# Altium schematic sheet 03_POWER_REGULATORS.SchDoc(03_POWER_REGULATORS)
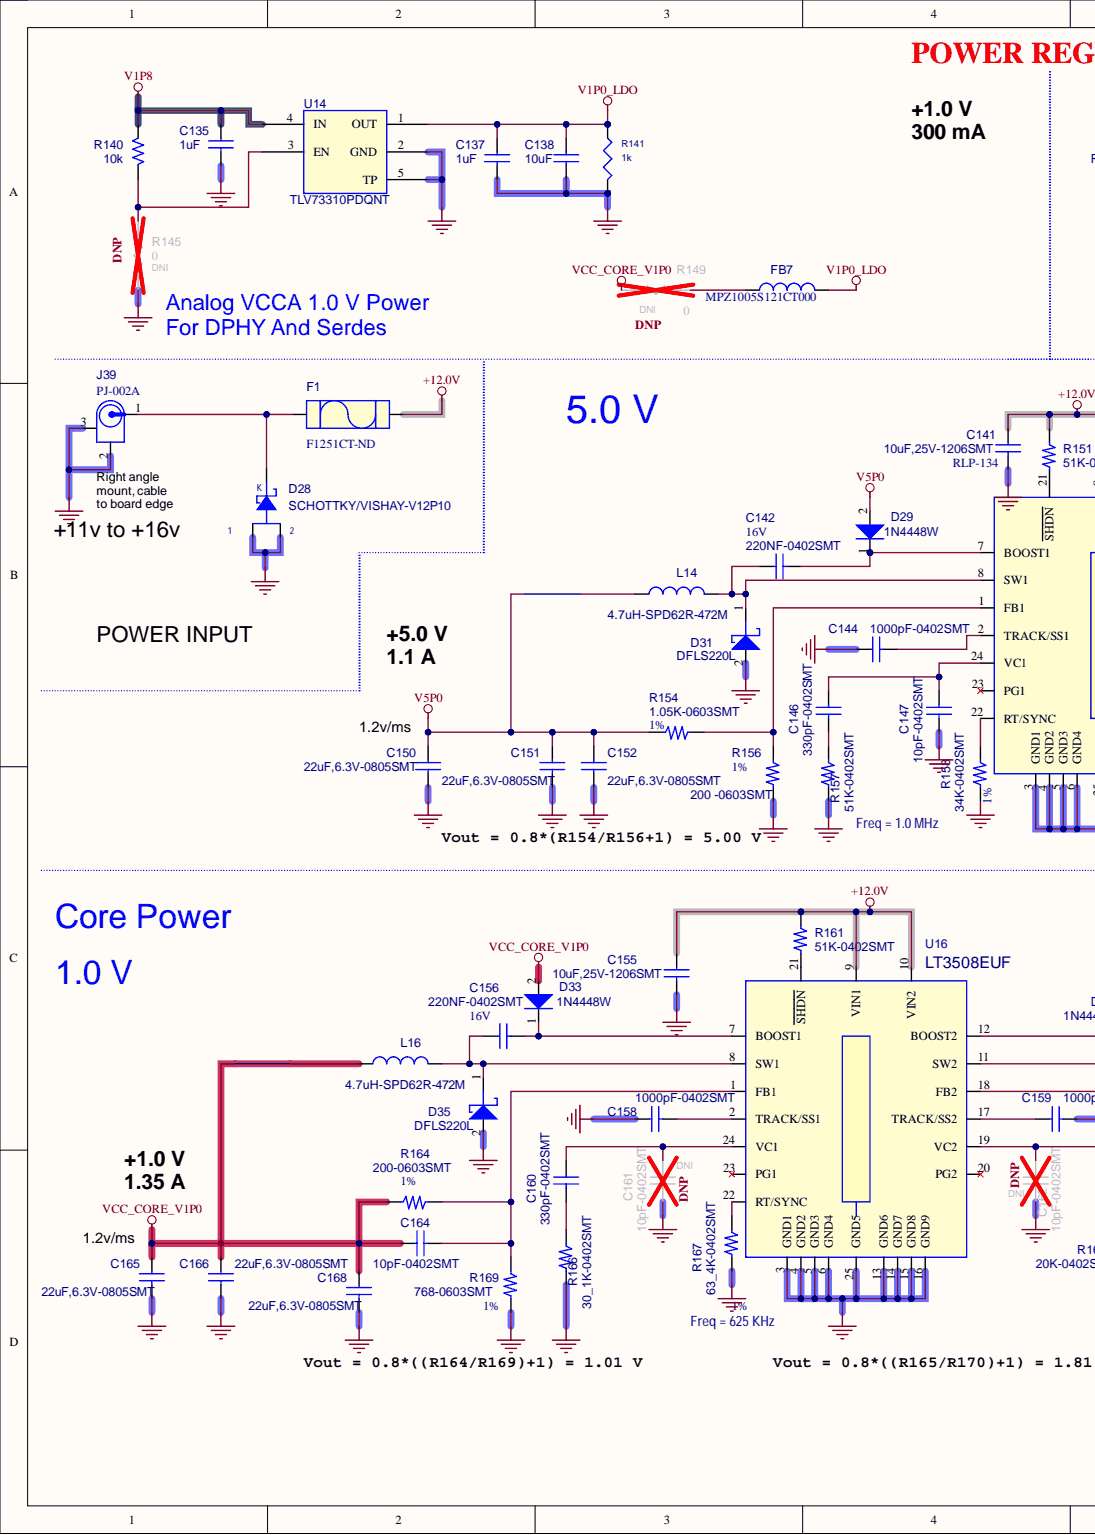
[diagram p0: 03_POWER_REGULATORS.SchDoc, left]
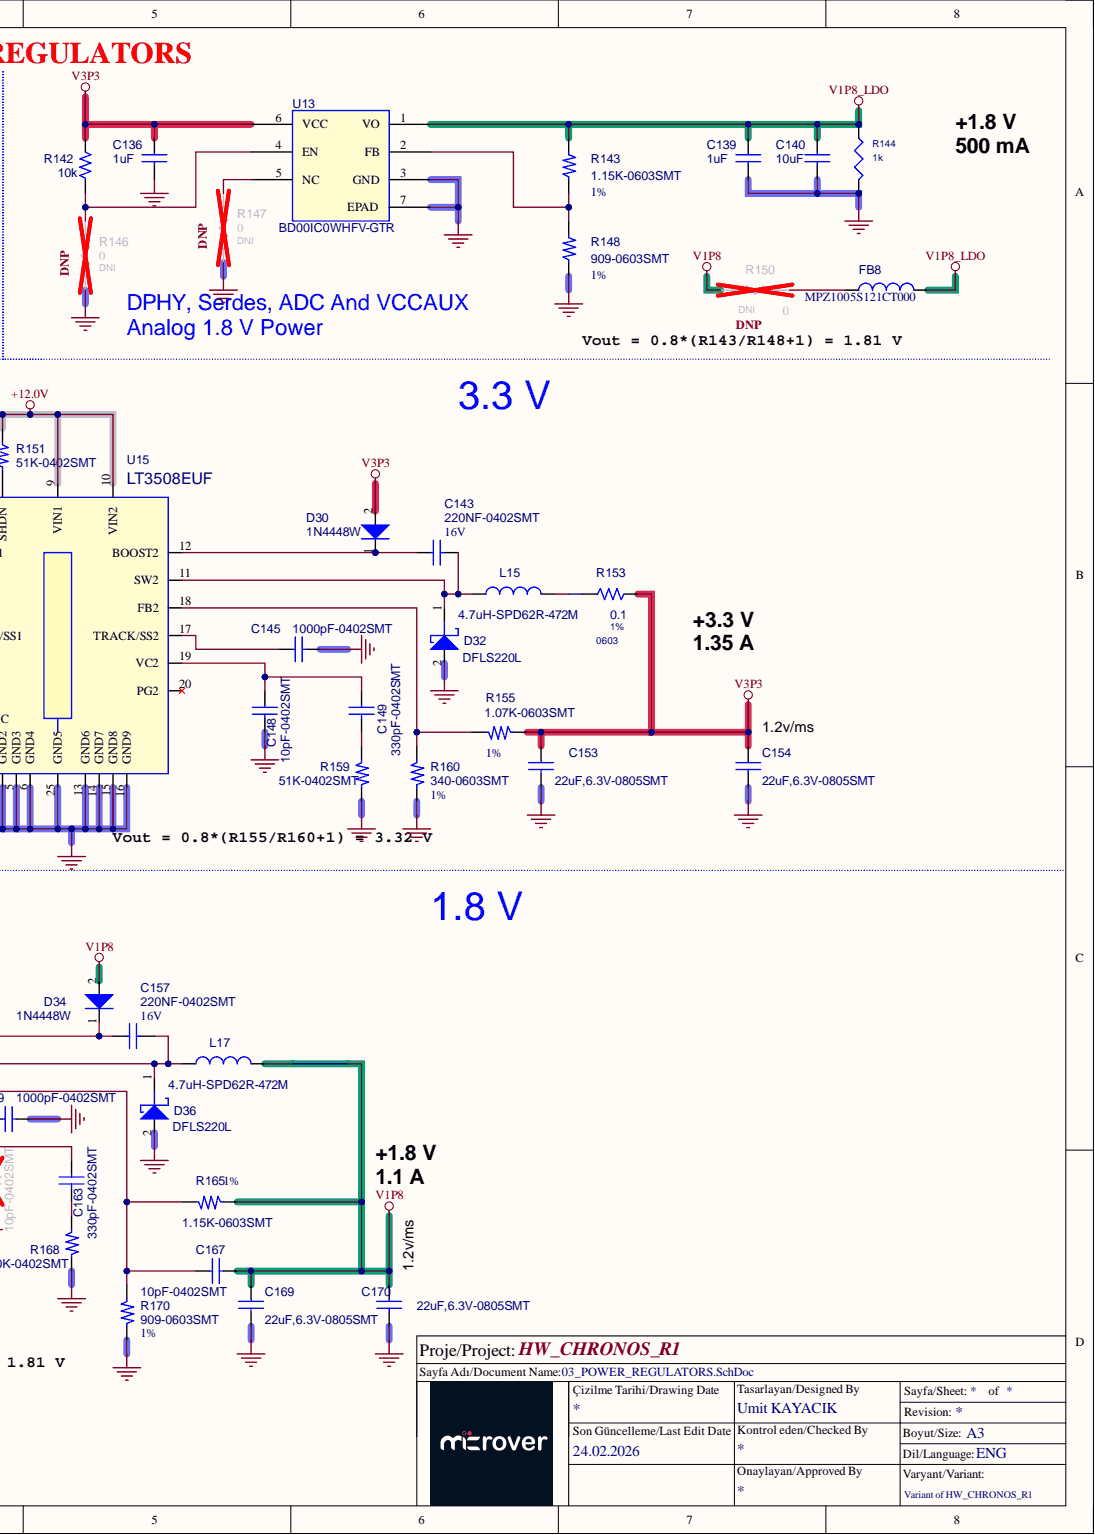
[diagram p1: 03_POWER_REGULATORS.SchDoc, right]

## Parts
C135  1uF  Part Reference: C135  [C0603]
C136  1uF  Part Reference: C136  [C0603]
C137  1uF  Part Reference: C137  [C0603]
C138  10uF  Part Reference: C138  [C0603]
C139  1uF  Part Reference: C139  [C0603]
C140  10uF  Part Reference: C140  [C0603]
C141  10uF,25V-1206SMT  Part Reference: C141  [RLP-134]
C142  220NF-0402SMT  COMPFIXED: 16V  Part Reference: C142  [RLP-130-A]
C143  220NF-0402SMT  COMPFIXED: 16V  Part Reference: C143  [RLP-130-A]
C144  1000pF-0402SMT  Part Reference: C144  [RLP-130-A]
C145  1000pF-0402SMT  Part Reference: C145  [RLP-130-A]
C146  330pF-0402SMT  Part Reference: C146  [RLP-130-A]
C147  10pF-0402SMT  Part Reference: C147  [RLP-130-A]
C148  10pF-0402SMT  Part Reference: C148  [RLP-130-A]
C149  330pF-0402SMT  Part Reference: C149  [RLP-130-A]
C150  22uF,6.3V-0805SMT  Part Reference: C150  [RLP-133]
C151  22uF,6.3V-0805SMT  Part Reference: C151  [RLP-133]
C152  22uF,6.3V-0805SMT  Part Reference: C152  [RLP-133]
C153  22uF,6.3V-0805SMT  Part Reference: C153  [RLP-133]
C154  22uF,6.3V-0805SMT  Part Reference: C154  [RLP-133]
C155  10uF,25V-1206SMT  Part Reference: C155  [RLP-134]
C156  220NF-0402SMT  COMPFIXED: 16V  Part Reference: C156  [RLP-130-A]
C157  220NF-0402SMT  COMPFIXED: 16V  Part Reference: C157  [RLP-130-A]
C158  1000pF-0402SMT  Part Reference: C158  [RLP-130-A]
C159  1000pF-0402SMT  Part Reference: C159  [RLP-130-A]
C160  330pF-0402SMT  Part Reference: C160  [RLP-130-A]
C161  10pF-0402SMT  ASSY: DNI  Part Reference: C161  Populate: DNI  [RLP-130-A]
C162  10pF-0402SMT  ASSY: DNI  Part Reference: C162  Populate: DNI  [RLP-130-A]
C163  330pF-0402SMT  Part Reference: C163  [RLP-130-A]
C164  10pF-0402SMT  Part Reference: C164  [RLP-130-A]
C165  22uF,6.3V-0805SMT  Part Reference: C165  [RLP-133]
C166  22uF,6.3V-0805SMT  Part Reference: C166  [RLP-133]
C167  10pF-0402SMT  Part Reference: C167  [RLP-130-A]
C168  22uF,6.3V-0805SMT  Part Reference: C168  [RLP-133]
C169  22uF,6.3V-0805SMT  Part Reference: C169  [RLP-133]
C170  22uF,6.3V-0805SMT  Part Reference: C170  [RLP-133]
D28  SCHOTTKY/VISHAY-V12P10  Part Reference: D28  [V12P10]
D29  1N4448W  Part Reference: D29  [1N4448W]
D30  1N4448W  [1N4448W]
D31  DFLS220L  Part Reference: D31  [DFLS220L]
D32  DFLS220L  Part Reference: D32  [DFLS220L]
D33  1N4448W  Part Reference: D33  [1N4448W]
D34  1N4448W  Part Reference: D34  [1N4448W]
D35  DFLS220L  Part Reference: D35  [DFLS220L]
D36  DFLS220L  Part Reference: D36  [DFLS220L]
F1  F1251CT-ND  Part Reference: F1  [0154010]
FB7  MPZ1005S121CT000  Part Reference: FB7  [FB0402]
FB8  MPZ1005S121CT000  Part Reference: FB8  [FB0402]
J39  PJ-002A  Part Reference: J39  [pj_002a_3p]
L14  4.7uH-SPD62R-472M  Part Reference: L14  [SPD62R]
L15  4.7uH-SPD62R-472M  Part Reference: L15  [SPD62R]
L16  4.7uH-SPD62R-472M  Part Reference: L16  [SPD62R]
L17  4.7uH-SPD62R-472M  Part Reference: L17  [SPD62R]
R140  10k  Part Reference: R140  [R0402]
R141  1k  Part Reference: R141  [R0402]
R142  10k  Part Reference: R142  [R0402]
R143  1.15K-0603SMT  COMPFIXED: 1%  Part Reference: R143  [RLP-101]
R144  1k  Part Reference: R144  [R0402]
R145  0  Assy: DNI  Part Reference: R145  [R0402]
R146  0  Assy: DNI  Part Reference: R146  [R0603]
R147  0  Assy: DNI  Part Reference: R147  [R0603]
R148  909-0603SMT  COMPFIXED: 1%  Part Reference: R148  [RLP-101]
R149  0  Assy: DNI  Part Reference: R149  [R0603]
R150  0  Assy: DNI  Part Reference: R150  [R0603]
R151  51K-0402SMT  Part Reference: R151  [RLP-100]
R153  0.1  Part Reference: R153  Tollerance: 1%  [0603]
R154  1.05K-0603SMT  COMPFIXED: 1%  Part Reference: R154  [RLP-101]
R155  1.07K-0603SMT  COMPFIXED: 1%  Part Reference: R155  [RLP-101]
R156  200 -0603SMT  COMPFIXED: 1%  Part Reference: R156  [RLP-101]
R157  51K-0402SMT  Part Reference: R157  [RLP-100]
R158  34K-0402SMT  COMPFIXED: 1%  Part Reference: R158  [RLP-100]
R159  51K-0402SMT  Part Reference: R159  [RLP-100]
R160  340-0603SMT  COMPFIXED: 1%  Part Reference: R160  [RLP-101]
R161  51K-0402SMT  Part Reference: R161  [RLP-100]
R164  200-0603SMT  COMPFIXED: 1%  [RLP-101]
R165  1.15K-0603SMT  COMPFIXED: 1%  Part Reference: R165  [RLP-101]
R166  30_1K-0402SMT  Part Reference: R166  [RLP-100]
R167  63_4K-0402SMT  COMPFIXED: 1%  Part Reference: R167  [RLP-100]
R168  20K-0402SMT  Part Reference: R168  [RLP-100]
R169  768-0603SMT  COMPFIXED: 1%  Part Reference: R169  [RLP-101]
R170  909-0603SMT  COMPFIXED: 1%  Part Reference: R170  [RLP-101]
U13  BD00IC0WHFV-GTR  Part Reference: U13  [6HVSOF_BD00IC0WHFV]
U14  TLV73310PDQNT  Part Reference: U14  [4X2SON]
U15  LT3508EUF  Part Reference: U15  [LT3508EUF]
U16  LT3508EUF  Part Reference: U16  [LT3508EUF]

## Nets
+12.0V: C141.1 C155.1 F1.2 R151.1 R161.1 U15.9(VIN1) U15.10(VIN2) U16.9(VIN1) U16.10(VIN2)
GND: C135.2 C136.2 C137.2 C138.2 C139.2 C140.2 C141.2 C144.1 C145.2 C147.2 C148.2 C150.2 C151.2 C152.2 C153.2 C154.2 C155.2 C158.1 C159.2 C161.2 C162.2 C165.2 C166.2 C168.2 C169.2 C170.2 D28.1 D28.2 D31.2 D32.2 D35.2 D36.2 J39.2 J39.3 R141.2 R144.2 R145.2 R146.2 R147.2 R148.1 R156.2 R157.2 R158.2 R159.2 R160.2 R166.2 R167.2 R168.2 R169.2 R170.2 U13.3(GND) U13.7(EPAD) U14.2(GND) U14.5(TP) U15.3(GND1) U15.4(GND2) U15.5(GND3) U15.6(GND4) U15.13(GND6) U15.14(GND7) U15.15(GND8) U15.16(GND9) U15.25(GND5) U16.3(GND1) U16.4(GND2) U16.5(GND3) U16.6(GND4) U16.13(GND6) U16.14(GND7) U16.15(GND8) U16.16(GND9) U16.25(GND5)
V1P0_LDO: C137.1 C138.1 FB7.2 R141.1 U14.1(OUT)
V1P8: C135.1 C167.2 C169.1 C170.1 D34.2 L17.1 R140.2 R150.2 R165.1 U14.4(IN)
V1P8_LDO: C139.1 C140.1 FB8.2 R143.2 R144.1 U13.1(VO)
V3P3: C136.1 C153.1 C154.1 D30.2 R142.2 R153.2 R155.1 U13.6(VCC)
V5P0: C150.1 C151.1 C152.1 D29.2 L14.1 R154.1
VCC_CORE_V1P0: C164.1 C165.1 C166.1 C168.1 D33.2 L16.1 R149.2 R164.1
NetC142_1: C142.1 D31.1 L14.2 U15.8(SW1)
NetC142_2: C142.2 D29.1 U15.7(BOOST1)
NetC143_1: C143.1 D30.1 U15.12(BOOST2)
NetC143_2: C143.2 D32.1 L15.2 U15.11(SW2)
NetC144_2: C144.2 U15.2(TRACK/SS1)
NetC145_1: C145.1 U15.17(TRACK/SS2)
NetC146_1: C146.1 C147.1 U15.24(VC1)
NetC146_2: C146.2 R157.1
NetC148_1: C148.1 C149.1 U15.19(VC2)
NetC149_2: C149.2 R159.1
NetC156_1: C156.1 D35.1 L16.2 U16.8(SW1)
NetC156_2: C156.2 D33.1 U16.7(BOOST1)
NetC157_1: C157.1 D34.1 U16.12(BOOST2)
NetC157_2: C157.2 D36.1 L17.2 U16.11(SW2)
NetC158_2: C158.2 U16.2(TRACK/SS1)
NetC159_1: C159.1 U16.17(TRACK/SS2)
NetC160_1: C160.1 C161.1 U16.24(VC1)
NetC160_2: C160.2 R166.1
NetC162_1: C162.1 C163.1 U16.19(VC2)
NetC163_2: C163.2 R168.1
NetC164_2: C164.2 R164.2 R169.1 U16.1(FB1)
NetC167_1: C167.1 R165.2 R170.1 U16.18(FB2)
NetD28_3: D28.3 F1.1 J39.1
NetFB7_1: FB7.1 R149.1
NetFB8_1: FB8.1 R150.1
NetL15_1: L15.1 R153.1
NetR140_1: R140.1 R145.1 U14.3(EN)
NetR142_1: R142.1 R146.1 U13.4(EN)
NetR143_1: R143.1 R148.2 U13.2(FB)
NetR147_1: R147.1 U13.5(NC)
NetR151_2: R151.2 U15.21(SHDN)
NetR154_2: R154.2 R156.1 U15.1(FB1)
NetR155_2: R155.2 R160.1 U15.18(FB2)
NetR158_1: R158.1 U15.22(RT/SYNC)
NetR161_2: R161.2 U16.21(SHDN)
NetR167_1: R167.1 U16.22(RT/SYNC)

Not connected: U15 20(PG2) 23(PG1); U16 20(PG2) 23(PG1)

## Library data of the parts
C135-C140 = CAP NP: CAP CER 10UF 10V 20% X6S 0603; Man. Part #: CL10X106MP8NRNC; Manufacturer: Samsung; Assy: -; Component Kind: Standard; Manufacturer Part Number: CL10X106MP8NRNC; MFG: Samsung; MFG P/N: CL10X106MP8NRNC; PCB Footprint: C0603
  C135-C137 C139: Value: 1uF
  C138 C140: Value: 10uF
C141-C149 C155-C164 C167 = CAP-NP: Component Kind: Standard
  C141 C155: Assy: -; PCB Footprint: RLP-134; Value: 10uF,25V-1206SMT
  C142 C143 C156 C157: Assy: -; PCB Footprint: RLP-130-A; Value: 220NF-0402SMT
  C144 C145 C158 C159: Assy: -; PCB Footprint: RLP-130-A; Value: 1000pF-0402SMT
  C146 C149 C160 C163: Assy: -; PCB Footprint: RLP-130-A; Value: 330pF-0402SMT
  C147 C148 C164 C167: Assy: -; PCB Footprint: RLP-130-A; Value: 10pF-0402SMT
  C161 C162: PCB Footprint: RLP-130-A; Value: 10pF-0402SMT
C150-C154 C165 C166 C168-C170 = CAP-NP: CAP CER 22UF 10V X5R 0805; Manufacturer: Samsung; Manufacturer Part Number: CL21A226KPCLRNC; Assy: -; Component Kind: Standard; PCB Footprint: RLP-133; Value: 22uF,6.3V-0805SMT
D28 = DIODE SCHOTTKY21: Assy: -; Component Kind: Standard; PCB Footprint: V12P10; Value: SCHOTTKY/VISHAY-V12P10
D29 D33 D34 = DIODE_0: Assy: -; Component Kind: Standard; PCB Footprint: 1N4448W; Value: 1N4448W
D30 = DIODE_0: Part Reference: D30; Assy: -; Component Kind: Standard; PCB Footprint: 1N4448W; Value: 1N4448W
D31 D32 D35 D36 = D-SCHOTTKY_0: Assy: -; Component Kind: Standard; PCB Footprint: DFLS220L; Value: DFLS220L
F1 = SMTFUSE_0: 5A Fast-Blo SMT Socketed Fuse; Assy: -; Component Kind: Standard; PCB Footprint: 0154010; Value: F1251CT-ND
FB7 FB8 = INDUCTOR_1: FERRITE BEAD 120 OHM 0402 1LN; Manufacturer: TDK Corporation; Assy: -; Component Kind: Standard; Manufacturer Part Number: MPZ1005S121CT000; PCB Footprint: FB0402; Value: MPZ1005S121CT000
J39 = 22HP037A: Male Power Jack 2.1mm; Assy: -; Component Kind: Standard; PCB Footprint: pj_002a_3p; Value: PJ-002A
L14 L16 = IND: COMPFIXED: SUMIDA; COMPGROUP: CDRH3D18; Assy: -; Component Kind: Standard; PCB Footprint: SPD62R; Value: 4.7uH-SPD62R-472M
L15 L17 = IND: COMPFIXED: SUMIDA; COMPGROUP: CDRH3D18C; Assy: -; Component Kind: Standard; PCB Footprint: SPD62R; Value: 4.7uH-SPD62R-472M
R140 R142 = R_Dup2: RES SMD 4.7K OHM 5% 1/10W 0402; Manufacturer: Panasonic; Manufacturer Part Number: ERJ-2GEJ472X; COMPFIXED: NO; COMPGROUP: 0; COMPHEIGHT: 0.000; COMPLOC: [970.000,1160.000]; COMPROT: 180; COMPSIDE: TOP; DIST: FLAT; FPLIST: AX/RC05,SM/R_0603; MAX_TEMP: RTMAX; POWER: RMAX; PSpiceTemplate: R^@REFDES %1 %2 ?TOLERANCE|R^@REFDES| @VALUE  ?TOLERANCE|\n.model R^@REFDES RES R=1 DEV=@TOLERANCE%|; SLOPE: RSMAX; VOLTAGE: RVMAX; Assy: -; COMPKEY: NO; COMPLOCKED: NO; Component Kind: Standard; DECOUPLER: NO; MEMORY: NO; MFG: Panasonic; MFG P/N: ERJ-2GEJ472X; PCB Footprint: R0402; Simulation: R; Value: 10k
  R140: Library Name: Chronos.SchLib
R141 R144 = RES_1K_1W16_0402_5P: RES SMD 1K OHM 1% 1/10W 0402; Manufacturer: Panasonic; Manufacturer Part Number: ERJ-2RKF1001X; DIST: FLAT; MAX_TEMP: RTMAX; MFG: Stackpole Electronics Inc; MFG P/N: RMCF0402JT1K00; TC1: 0; Total Area(sq-mm): 0.5; Unit Price (USD): 0.02; Wattage: 1/16W; Assy: -; Component Kind: Standard; Length(mm): 1; PCB Footprint: R0402; TC2: 0; Value: 1k; Width(mm): 0.5
  R141: Reference: R141
  R144: Reference: R144
R143 R148 R151 R154-R156 R160 R161 R165 R169 R170 = R_Dup1: Assy: -; Component Kind: Standard
  R143 R165: PCB Footprint: RLP-101; Value: 1.15K-0603SMT
  R148 R170: PCB Footprint: RLP-101; Value: 909-0603SMT
  R151 R161: PCB Footprint: RLP-100; Value: 51K-0402SMT
  R154: PCB Footprint: RLP-101; Value: 1.05K-0603SMT
  R155: PCB Footprint: RLP-101; Value: 1.07K-0603SMT
  R156: PCB Footprint: RLP-101; Value: 200 -0603SMT
  R160: PCB Footprint: RLP-101; Value: 340-0603SMT
  R169: PCB Footprint: RLP-101; Value: 768-0603SMT
R145 = 0R_0603: RES 0.0 OHM 1/10W JUMP 0402 SMD; Manufacturer: Yageo; Manufacturer Part Number: RC0603JR-070RL; Class: Discrete; PCB Footprint: R0603; Component Kind: Standard; Digikey P/N: 311-0.0GRTR-ND; Value: 0
R146 R147 R149 R150 = 0R_0603: RES 0.0 OHM 1/10W JUMP 0603 SMD; Manufacturer: Yageo; Manufacturer Part Number: RC0603JR-070RL; Class: Discrete; Component Kind: Standard; Digikey P/N: 311-0.0GRTR-ND; PCB Footprint: R0603; Value: 0
R153 = R_Dup2: COMPFIXED: NO; COMPGROUP: 0; COMPHEIGHT: 0.000; COMPLOC: [970.000,1160.000]; COMPROT: 180; COMPSIDE: TOP; DIST: FLAT; FPLIST: AX/RC05,SM/R_0603; MAX_TEMP: RTMAX; POWER: RMAX; PSpiceTemplate: R^@REFDES %1 %2 ?TOLERANCE|R^@REFDES| @VALUE  ?TOLERANCE|\n.model R^@REFDES RES R=1 DEV=@TOLERANCE%|; SLOPE: RSMAX; VOLTAGE: RVMAX; Assy: -; COMPKEY: NO; COMPLOCKED: NO; Component Kind: Standard; DECOUPLER: NO; MEMORY: NO; PCB Footprint: 0603; Simulation: R; Value: 0.1
R157 R159 R166 R168 = R_Dup1: COMPFIXED: 1%; Assy: -; Component Kind: Standard; PCB Footprint: RLP-100
  R157 R159: Value: 51K-0402SMT
  R166: Value: 30_1K-0402SMT
  R168: Value: 20K-0402SMT
R158 = R_Dup1: COMPGROUP: Freq = 1.0 MHz; Assy: -; Component Kind: Standard; PCB Footprint: RLP-100; Value: 34K-0402SMT
R164 = R_Dup1: Part Reference: R164; Assy: -; Component Kind: Standard; PCB Footprint: RLP-101; Value: 200-0603SMT
R167 = R_Dup1: COMPGROUP: Freq = 625 KHz; Assy: -; Component Kind: Standard; PCB Footprint: RLP-100; Value: 63_4K-0402SMT
U15 U16 = LT3508EUF-QFN24UF-4X4_0: DES: IC, LINEAR TECH, LTC1563; UCOST: $0.00; Assy: -; Component Kind: Standard; PCB Footprint: LT3508EUF; Value: LT3508EUF
U13 = BD00IC0WHFV-GTR: Assy: -; Component Kind: Standard; PCB Footprint: 6HVSOF_BD00IC0WHFV; Value: BD00IC0WHFV-GTR
U14 = TLV73310PDQNT: Assy: -; Component Kind: Standard; PCB Footprint: 4X2SON; Value: TLV73310PDQNT
